# Altium schematic sheet Shutdown_Middle.SchDoc(Shutdown_Middle)
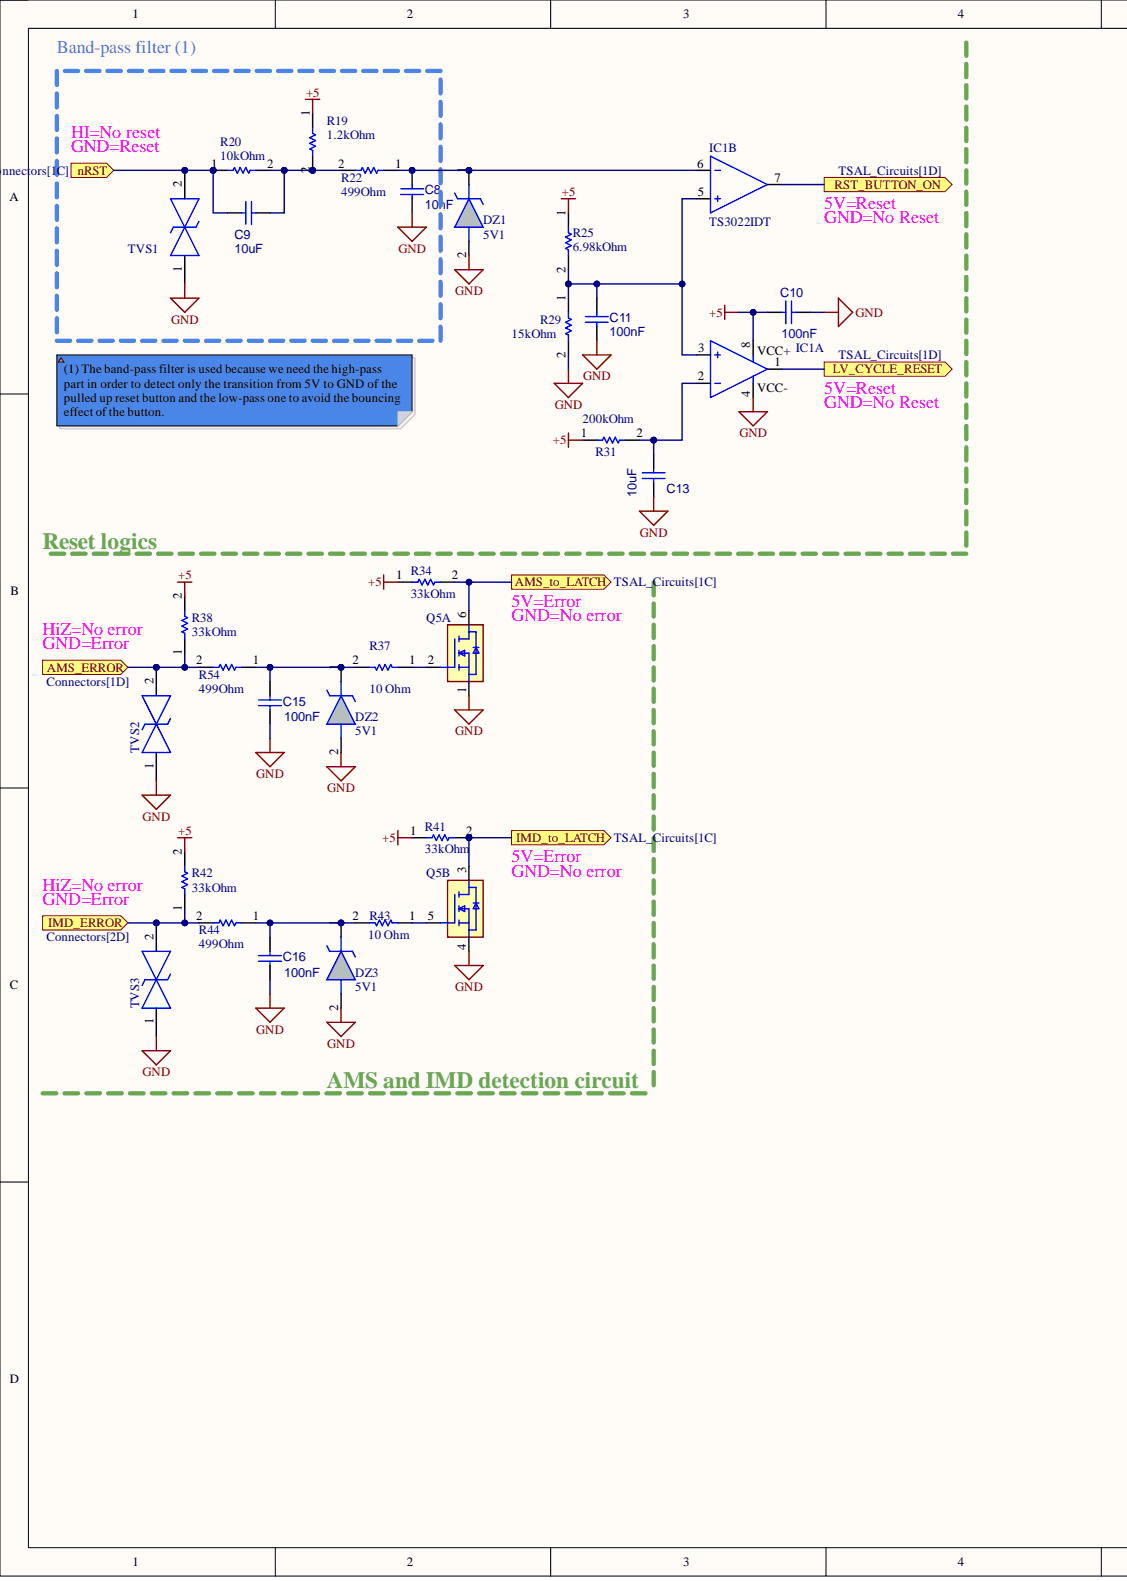
[diagram p0: Shutdown_Middle.SchDoc, left]
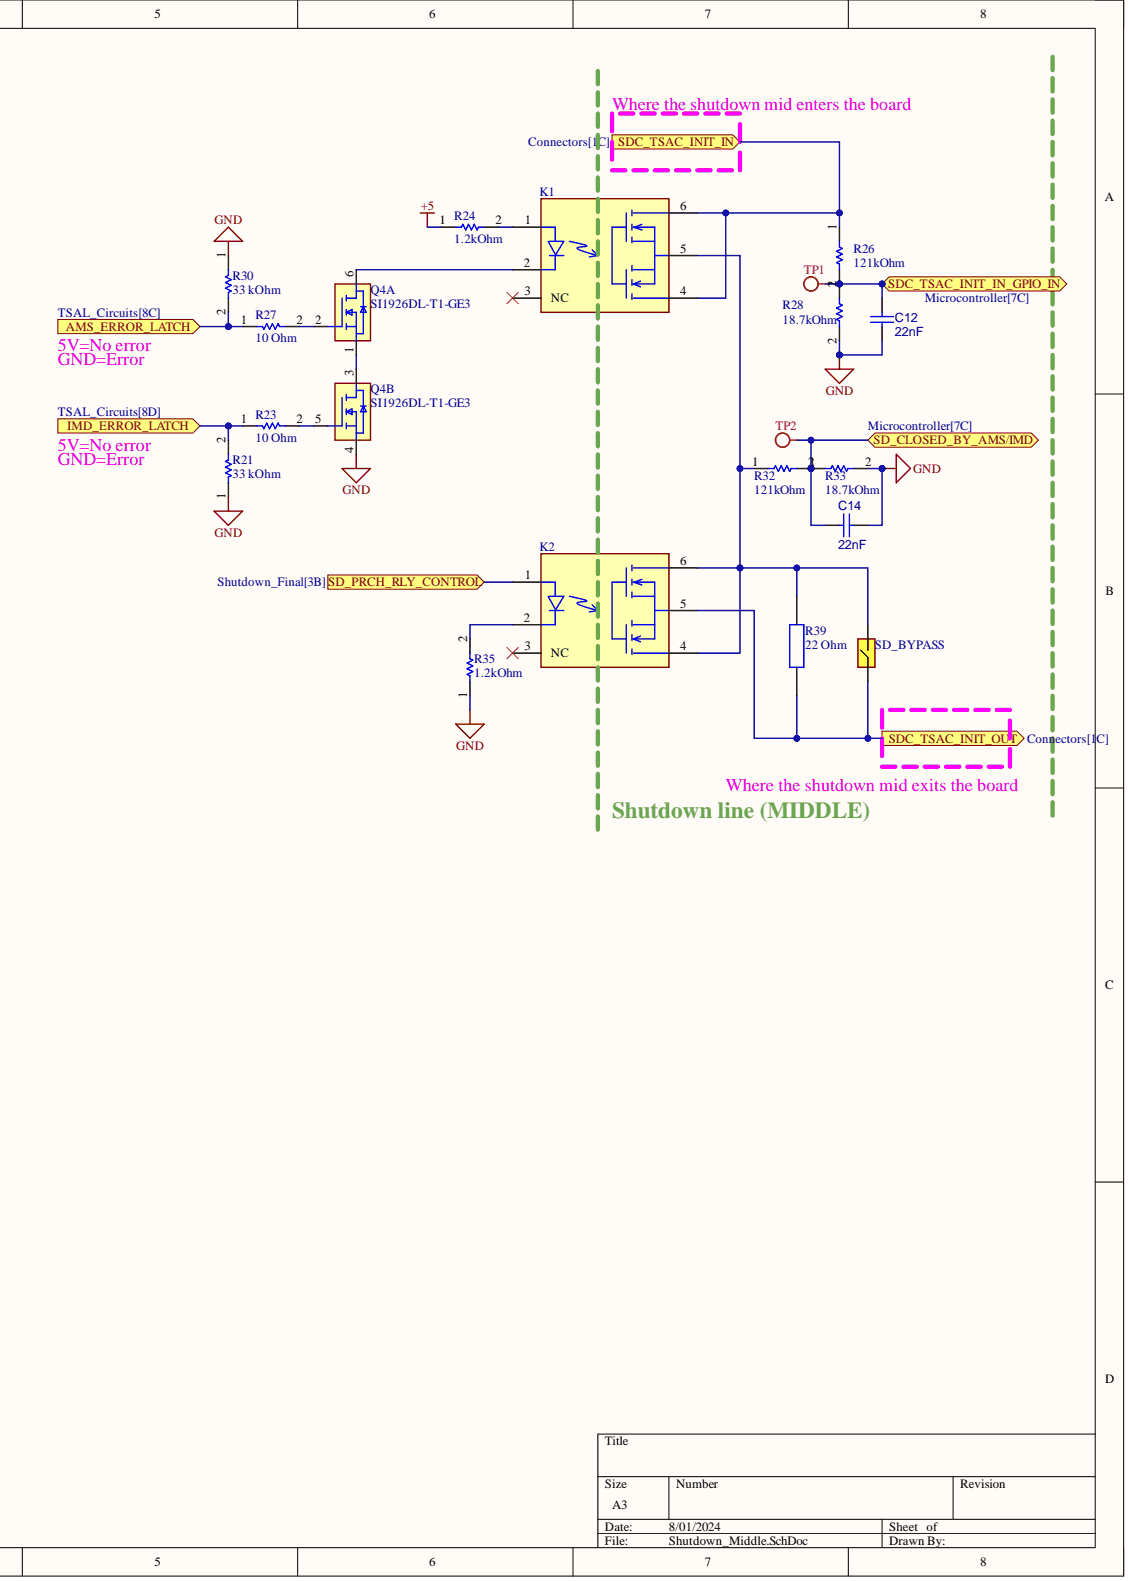
[diagram p1: Shutdown_Middle.SchDoc, right]

## Parts
C8  10nF
C9  10uF
C10  100nF
C11  100nF
C12  22nF
C13  10uF
C14  22nF
C15  100nF
C16  100nF
DZ1  5V1
DZ2  5V1
DZ3  5V1
IC1  TS3022IDT  (parts A,B)
K1  Solid-State Relay  (value not drawn)
K2  Solid-State Relay  (value not drawn)
Q4  SI1926DL-T1-GE3  (parts A,B)
Q5  SI1926DL-T1-GE3  (parts A,B)
R19  1.2kOhm
R20  10kOhm
R21  33 kOhm
R22  499Ohm
R23  10 Ohm
R24  1.2kOhm
R25  6.98kOhm
R26  121kOhm
R27  10 Ohm
R28  18.7kOhm
R29  15kOhm
R30  33 kOhm
R31  200kOhm
R32  121kOhm
R33  18.7kOhm
R34  33kOhm
R35  1.2kOhm
R37  10 Ohm
R38  33kOhm
R39  22 Ohm
R41  33kOhm
R42  33kOhm
R43  10 Ohm
R44  499Ohm
R54  499Ohm
SD_BYPASS  SolderBridge_0603  (value not drawn)
TP1  Test Point  (value not drawn)
TP2  Test Point  (value not drawn)
TVS1  SMAJ30CA-TR  (value not drawn)
TVS2  SMAJ30CA-TR  (value not drawn)
TVS3  SMAJ30CA-TR  (value not drawn)

## Ports
AMS_ERROR, AMS_ERROR_LATCH, AMS_to_LATCH, IMD_ERROR, IMD_ERROR_LATCH, IMD_to_LATCH, LV_CYCLE_RESET, nRST, RST_BUTTON_ON, SD_CLOSED_BY_AMS/IMD, SD_PRCH_RLY_CONTROL, SDC_TSAC_INIT_IN, SDC_TSAC_INIT_IN_GPIO_IN, SDC_TSAC_INIT_OUT

## Nets
+5: C10.1 IC1.8 R19.1 R24.1 R25.1 R31.1 R34.1 R38.2 R41.1 R42.2
GND: C8.1 C10.2 C11.2 C12.2 C13.1 C14.2 C15.2 C16.2 DZ1.2 DZ2.2 DZ3.2 IC1.4 Q4.4 Q5.1 Q5.4 R21.1 R28.2 R29.2 R30.1 R33.2 R35.1 TVS1.1 TVS2.1 TVS3.1
AMS_ERROR: R38.1 R54.2 TVS2.2 ; port AMS_ERROR
AMS_ERROR_LATCH: R27.1 R30.2 ; port AMS_ERROR_LATCH
AMS_to_LATCH: Q5.6 R34.2 ; port AMS_to_LATCH
IMD_ERROR: R42.1 R44.2 TVS3.2 ; port IMD_ERROR
IMD_ERROR_LATCH: R21.2 R23.1 ; port IMD_ERROR_LATCH
IMD_to_LATCH: Q5.3 R41.2 ; port IMD_to_LATCH
LV_CYCLE_RESET: IC1.1 ; port LV_CYCLE_RESET
nRST: C9.1 R20.1 TVS1.2 ; port nRST
RST_BUTTON_ON: IC1.7 ; port RST_BUTTON_ON
SD_CLOSED_BY_AMS/IMD: C14.1 R32.2 R33.1 TP2.1 ; port SD_CLOSED_BY_AMS/IMD
SD_PRCH_RLY_CONTROL: K2.1 ; port SD_PRCH_RLY_CONTROL
SDC_TSAC_INIT_IN: K1.4 K1.6 R26.1 ; port SDC_TSAC_INIT_IN
SDC_TSAC_INIT_IN_GPIO_IN: C12.1 R26.2 R28.1 TP1.1 ; port SDC_TSAC_INIT_IN_GPIO_IN
SDC_TSAC_INIT_OUT: K2.5 R39.2 SD_BYPASS.1 ; port SDC_TSAC_INIT_OUT
NetC8_2: C8.2 DZ1.1 IC1.6 R22.1
NetC9_2: C9.2 R19.2 R20.2 R22.2
NetC11_1: C11.1 IC1.3 IC1.5 R25.2 R29.1
NetC13_2: C13.2 IC1.2 R31.2
NetC15_1: C15.1 DZ2.1 R37.2 R54.1
NetC16_1: C16.1 DZ3.1 R43.2 R44.1
NetK1_1: K1.1 R24.2
NetK1_2: K1.2 Q4.6
NetK1_5: K1.5 K2.4 K2.6 R32.1 R39.1 SD_BYPASS.2
NetK2_2: K2.2 R35.2
NetQ4_1: Q4.1 Q4.3
NetQ4_2: Q4.2 R27.2
NetQ4_5: Q4.5 R23.2
NetQ5_2: Q5.2 R37.1
NetQ5_5: Q5.5 R43.1

Not connected: K1 3(NC); K2 3(NC)

## Library data of the parts
C8 = CMP-001-00085-5: VJ0805H103KXBBC31; Tech: SMD; Component Kind: Standard; ComponentLink1Description: Website; Package: 0805; Library Name: EMEA- Squadra Corse PoliTO-  Politecnico di Torino
C9 = CMP-001-00085-5: CL21B105KBFNNNE; Tech: SMD; Component Kind: Standard; ComponentLink1Description: Website; Package: 0805; Library Name: EMEA- Squadra Corse PoliTO-  Politecnico di Torino
C10 C11 C15 C16 = CMP-001-00085-5: VJ0805Y104MXATW1BC; Tech: SMD; Component Kind: Standard; ComponentLink1Description: Website; Package: 0805; Library Name: EMEA- Squadra Corse PoliTO-  Politecnico di Torino
C12 C14 = CMP-001-00085-5: VJ0805Y223JXACW1BC; Tech: SMD; Component Kind: Standard; ComponentLink1Description: Website; Package: 0805; Library Name: EMEA- Squadra Corse PoliTO-  Politecnico di Torino
C13 = CMP-001-00085-5: GRM21BR71A106KA73K; Tech: SMD; Component Kind: Standard; ComponentLink1Description: Website; Package: 0805; Library Name: EMEA- Squadra Corse PoliTO-  Politecnico di Torino
DZ1-DZ3 = CMP-002-000008-4: MMSZ5231C-E3-08; Manufacturer_Name: Vishay; Geometry.Height: 1.35mm; Component Kind: Standard; Datasheet Link: https://www.arrow.com/en/products/mmsz5231c-e3-08/vishay; Design Item ID: MMSZ5231C-E3-08; Manufacturer_Part_Number: MMSZ5231C-E3-08; Mouser Part Number: 78-MMSZ5231C-E3-08; Mouser Price/Stock: https://www.mouser.co.uk/ProductDetail/Vishay-Semiconductors/MMSZ5231C-E3-08?qs=S660mHfF5o35EDHKMfkp8w%3D%3D; Source: MMSZ5231C-E3-08.SchLib; Library Name: EMEA- Squadra Corse PoliTO-  Politecnico di Torino
IC1 = CMP-030-000040-3: Manufacturer_Name: STMicroelectronics; Arrow Part Number: TS3022IDT; Arrow Price/Stock: https://www.arrow.com/en/products/ts3022idt/stmicroelectronics; Component Kind: Standard; Datasheet Link: http://www.st.com/st-web-ui/static/active/en/resource/technical/document/datasheet/CD00222487.pdf; Description: TS3022IDT; Design Item ID: TS3022IDT; Height: 1.75mm; Manufacturer_Part_Number: TS3022IDT; Mouser Part Number: 511-TS3022IDT; Mouser Price/Stock: https://www.mouser.co.uk/ProductDetail/STMicroelectronics/TS3022IDT?qs=%252BFmDY%2FmH7IbwI8iiFoislw%3D%3D; Source: SamacSys.SchLib; Library Name: EMEA- Squadra Corse PoliTO-  Politecnico di Torino
K1 K2 = CMP-2000-07398-2: VO14642AABTR; Manufacturer: Vishay; Input Current: 50mA; Isolation Voltage: 5300Vrms; Max Junction Temp: +125°C; Max Power Dissipation: 330mW; Number Of Contacts: 1; On State Resistance: 0.25Ω; Output Current: 2A; Output Voltage: 60V; Packag: SMD DIP-6; Standoff Height: 0.05mm; Tech: SMD; Throw Configuration: SPST-NO; Ambient Temperature Range High: +85°C; Ambient Temperature Range Low: -55°C; Automotive: No; Category: Relay; Component Kind: Standard; ComponentLink2Description: Datasheet; ComponentLink2URL: http://www.vishay.com/docs/81646/vo14642a.pdf; ComponentLink3Description: Website; ComponentLink3URL: https://www.mouser.it/ProductDetail/Vishay-Semiconductors/VO14642AABTR?qs=%2Fjqivxn91cdjDkQHAFlOvw%3D%3D; Device Class L1: Electromechanical; Device Class L2: Relays; Device Class L3: Solid State Relays; Digikey Description: SSR RELAY SPST-NO 2A 0-60V; Height: 4.25mm; Ipc Land Pattern Name: SOIC254P980X425-6; Lead Free: Yes; Library Name: Altium Content Vault; Manufacturer Part Number: VO14642AABTR; Mouser Description: Solid State Relays - PCB Mount Normally Open Form 1A 60V; Octopart: https://octopart.com/vo14642aabtr-vishay-42763680; Package: VO14642AABTR; RoHS: TRUE; Temperature: to +125°C; Temperature Range High: +125°C
Q4 Q5 = CMP-009-000004-2: MOSFET (N-Channel); Manufacturer_Name: Vishay; Arrow Part Number: SI1926DL-T1-GE3; Arrow Price/Stock: https://www.arrow.com/en/products/si1926dl-t1-ge3/vishay; Component Kind: Standard; Datasheet Link: https://www.vishay.com/docs/73684/si1926dl.pdf; Design Item ID: SI1926DL-T1-GE3; Height: 1.1mm; Manufacturer_Part_Number: SI1926DL-T1-GE3; Mouser Part Number: 78-SI1926DL-T1-GE3; Mouser Price/Stock: https://www.mouser.com/Search/Refine.aspx?Keyword=78-SI1926DL-T1-GE3; Source: SamacSys.SchLib; Library Name: EMEA- Squadra Corse PoliTO-  Politecnico di Torino
R19 R24 R35 = CMP-009-00179-5: CRCW06031K20FKEAHP; Tech: SMD; Component Kind: Standard; ComponentLink1Description: Website; Package: 0603; Library Name: EMEA- Squadra Corse PoliTO-  Politecnico di Torino
R20 = CMP-009-00179-5: CRCW060310K0FKEA; Tech: SMD; Component Kind: Standard; ComponentLink1Description: Website; Package: 0603; Library Name: EMEA- Squadra Corse PoliTO-  Politecnico di Torino
R21 R30 = CMP-009-00179-5: RCS060333K0FKEA; Tech: SMD; Component Kind: Standard; ComponentLink1Description: Website; Package: 0603; Library Name: EMEA- Squadra Corse PoliTO-  Politecnico di Torino
R22 R44 R54 = CMP-009-00179-5: CRCW0603499RFKEAHP; Tech: SMD; Component Kind: Standard; ComponentLink1Description: Website; Package: 0603; Library Name: EMEA- Squadra Corse PoliTO-  Politecnico di Torino
R23 R27 R37 R43 = CMP-009-00179-5: CRCW060310R0FKEAHP; Tech: SMD; Component Kind: Standard; ComponentLink1Description: Website; Package: 0603; Library Name: EMEA- Squadra Corse PoliTO-  Politecnico di Torino
R25 = CMP-009-00179-5: CRCW06036K98FKTA; Tech: SMD; Component Kind: Standard; ComponentLink1Description: Website; Package: 0603; Library Name: EMEA- Squadra Corse PoliTO-  Politecnico di Torino
R26 R32 = CMP-009-00179-5: CRCW0603121KFKEA; Tech: SMD; Component Kind: Standard; ComponentLink1Description: Website; Package: 0603; Library Name: EMEA- Squadra Corse PoliTO-  Politecnico di Torino
R28 R33 = CMP-009-00179-5: CRCW060318K7FKEA; Tech: SMD; Component Kind: Standard; ComponentLink1Description: Website; Package: 0603; Library Name: EMEA- Squadra Corse PoliTO-  Politecnico di Torino
R29 = CMP-009-00179-5: CRCW060315K0FKTA; Tech: SMD; Component Kind: Standard; ComponentLink1Description: Website; Package: 0603; Library Name: EMEA- Squadra Corse PoliTO-  Politecnico di Torino
R31 = CMP-009-00179-5: CRCW0603200KFKEB; Tech: SMD; Component Kind: Standard; ComponentLink1Description: Website; Package: 0603; Library Name: EMEA- Squadra Corse PoliTO-  Politecnico di Torino
R34 R38 R41 R42 = CMP-009-00179-5: CRCW060333K0FKEAC; Tech: SMD; Component Kind: Standard; ComponentLink1Description: Website; Package: 0603; Library Name: EMEA- Squadra Corse PoliTO-  Politecnico di Torino
R39 = CMP-085-000000-6: CW00522R00JE73; Tech: THT; Component Kind: Standard; Package: 25.4x9.7 mm; Library Name: EMEA- Squadra Corse PoliTO-  Politecnico di Torino
SD_BYPASS = CMP-000007-2: Component Kind: Standard; Description: SolderBridge_0603; Library Name: EMEA- Squadra Corse PoliTO-  Politecnico di Torino
TP1 TP2 = CMP-002-00071-2: Component Kind: Standard; Description: Test Point; Library Name: EMEA- Squadra Corse PoliTO-  Politecnico di Torino
TVS1-TVS3 = CMP-004-000005-1: TVS Diode Bi-Directional SMAJ30CA-TR 64.3V; Manufacturer_Name: STMicroelectronics; Arrow Part Number: SMAJ30CA-TR; Arrow Price/Stock: https://www.arrow.com/en/products/smaj30ca-tr/stmicroelectronics?region=nac; Component Kind: Standard; Datasheet Link: https://www.st.com/resource/en/datasheet/smaj10ca.pdf; Design Item ID: SMAJ30CA-TR; Height: 2.65mm; Manufacturer_Part_Number: SMAJ30CA-TR; Mouser Part Number: 511-SMAJ30CA-TR; Mouser Price/Stock: https://www.mouser.co.uk/ProductDetail/STMicroelectronics/SMAJ30CA-TR?qs=0TJjK1waviDY0EkLaKH12g%3D%3D; Source: SamacSys.SchLib; Library Name: EMEA- Squadra Corse PoliTO-  Politecnico di Torino
